# Altium schematic sheet powerpath_controller.SchDoc
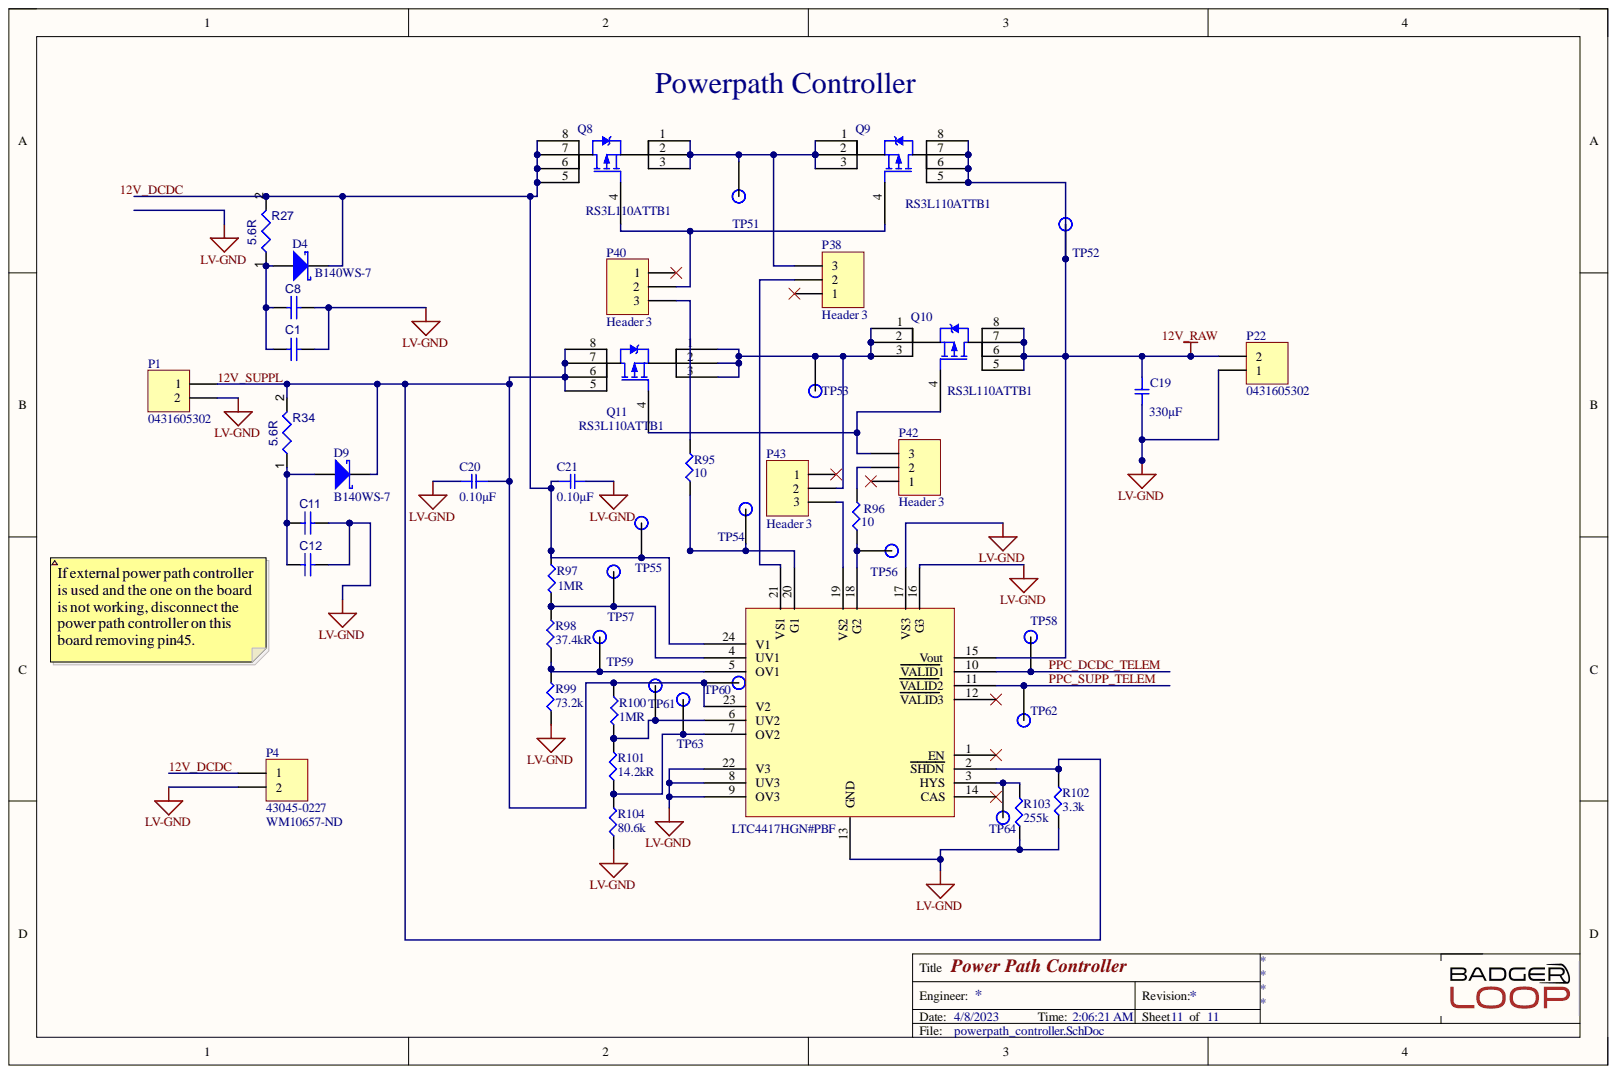
[diagram p0: powerpath_controller.SchDoc]

## Parts
C1  35YXJ2200M16X25  [35YXJ2200M16X25-Footprint-1]  (value not drawn)
C8  35YXJ2200M16X25  [35YXJ2200M16X25-Footprint-1]  (value not drawn)
C11  35YXJ2200M16X25  [35YXJ2200M16X25-Footprint-1]  (value not drawn)
C12  35YXJ2200M16X25  [35YXJ2200M16X25-Footprint-1]  (value not drawn)
C19  Capacitance: 330µF  [CAPAE110103X110N]  (hidden comment: GYB1E331MCW1GS)
C20  0.10µF  [SMD-0805C]
C21  0.10µF  [SMD-0805C]
D4  B140WS-7  [SODFL250X110-2N]
D9  B140WS-7  [SODFL250X110-2N]
P1  0431605302  [CONN HDR 2POS 7.50MM]
P4  43045-0227  Supplier Part Number 1: WM10657-ND  [43045-0277]
P22  0431605302  [CONN HDR 2POS 7.50MM]
P38  Header 3  [Pin Header 1x3 TH Pitch 2.54mm]
P40  Header 3  [Pin Header 1x3 TH Pitch 2.54mm]
P42  Header 3  [Pin Header 1x3 TH Pitch 2.54mm]
P43  Header 3  [Pin Header 1x3 TH Pitch 2.54mm]
Q8  RS3L110ATTB1  [RS3L110AT]
Q9  RS3L110ATTB1  [RS3L110AT]
Q10  RS3L110ATTB1  [RS3L110AT]
Q11  RS3L110ATTB1  [RS3L110AT]
R27  5.6R  [FP-RMCF2512-IPC_C]
R34  5.6R  [FP-RMCF2512-IPC_C]
R95  10  [SMD-0805-RES]
R96  10  [SMD-0805-RES]
R97  1MR  [SMD-0805-RES]
R98  37.4kR  [SMD-0805-RES]
R99  73.2k  [SMD-1206-RES]
R100  1MR  [SMD-0805-RES]
R101  14.2kR  [SMD-0805-RES]
R102  3.3k  [SMD-1206-RES]
R103  255k  [SMD-1206-RES]
R104  80.6k  [SMD-0805-RES]
TP51  TP  [Test Point]
TP52  TP  [Test Point]
TP53  TP  [Test Point]
TP54  TP  [Test Point]
TP55  TP  [Test Point]
TP56  TP  [Test Point]
TP57  TP  [Test Point]
TP58  TP  [Test Point]
TP59  TP  [Test Point]
TP60  TP  [Test Point]
TP61  TP  [Test Point]
TP62  TP  [Test Point]
TP63  TP  [Test Point]
TP64  TP  [Test Point]
U16  LTC4417HGN#PBF  [LT-GN-24_L]

## Nets
12V_RAW: C19.1 P22.2 Q9.5 Q9.6 Q9.7 Q9.8 Q10.5 Q10.6 Q10.7 Q10.8 TP52.1 U16.15(Vout)
LV-GND: C1.2 C8.2 C11.2 C12.2 C19.2 C20.2 C21.2 P1.2 P4.2 P22.1 R99.1 R102.1 R103.1 R104.1 U16.8(UV3) U16.9(OV3) U16.13(GND) U16.16(G3) U16.17(VS3) U16.22(V3)
12V_DCDC: P4.1
12V_SUPPL: C20.1 D9.2 P1.1 Q11.5 Q11.6 Q11.7 Q11.8 R34.2 R100.1 R102.2 TP60.1 U16.2(SHDN) U16.23(V2)
PPC_DCDC_TELEM: TP58.1 U16.10(VALID1)
PPC_SUPP_TELEM: TP62.1 U16.11(VALID2)
NetC1_1: C1.1 C8.1 D4.1 R27.1
NetC11_1: C11.1 C12.1 D9.1 R34.1
NetC21_1: C21.1 D4.2 Q8.5 Q8.6 Q8.7 Q8.8 R27.2 R97.1 TP55.1 U16.24(V1)
NetP38_2: P38.2 U16.21(VS1)
NetP38_3: P38.3 Q8.1 Q8.2 Q8.3 Q9.1 Q9.2 Q9.3 TP51.1
NetP40_2: P40.2 Q8.4 Q9.4
NetP40_3: P40.3 R95.2
NetP42_2: P42.2 R96.2
NetP42_3: P42.3 Q10.4 Q11.4
NetP43_2: P43.2 Q10.1 Q10.2 Q10.3 Q11.1 Q11.2 Q11.3 TP53.1
NetP43_3: P43.3 U16.19(VS2)
NetR95_1: R95.1 TP54.1 U16.20(G1)
NetR96_1: R96.1 TP56.1 U16.18(G2)
NetR97_2: R97.2 R98.2 TP57.1 U16.4(UV1)
NetR98_1: R98.1 R99.2 TP59.1 U16.5(OV1)
NetR100_2: R100.2 R101.2 TP61.1 U16.6(UV2)
NetR101_1: R101.1 R104.2 TP63.1 U16.7(OV2)
NetR103_2: R103.2 TP64.1 U16.3(HYS)

Not connected: P38 1; P40 1; P42 1; P43 1; U16 1(EN) 12(VALID3) 14(CAS)

## Library data of the parts
C1 C8 C11 C12 = CMP-001-00497-1: Aluminum Electrolytic Capacitor, Polarized, Aluminum (wet), 35V, 20% +Tol, 20% -Tol, 2200uF; Capacitor Type: Aluminium; Ripple Current: 2.93A; Component Kind: Standard; Library Name: Altium Concord Pro on A365; Max Operating Temperature: 105°C; Min Operating Temperature: -40°C; RoHS Compliant: Yes
C19 = GYB1E331MCW1GS: Aluminum Organic Polymer Capacitors 25V 330uf; Component Kind: Standard; ComponentLink1Description: Link; ComponentLink1URL: https://www.mouser.com/ProductDetail/Nichicon/GYB1E331MCW1GS?qs=sGAEpiMZZMvwFf0viD3Y3a3yb5D6sPUgteTcG6zlWVz7Qxll6G9Nxw%3D%3D; Library Name: capacitors.SchLib
C20 C21 = GCJ21BR71H104KA01L: CAP CER 0.1UF 50V X7R 0805; Manufacturer: Murata Electronics North America; @ qty: 0; Minimum Quantity: 1; Tolerance: ±10%; Unit Price (USD): 0.24; Applications: Automotive, Boardflex Sensitive; Component Kind: Standard; Datasheets: http://www.murata.com/~/media/webrenewal/support/library/catalog/products/capacitor/mlcc/c03e.ashx; Digi-Key Part Number: 490-5803-1-ND; Factory Stock: 0; Features: Soft Termination; Height - Seated (Max): -; Image: //media.digikey.com/Renders/Murata%20Renders/0805%20(2012%20Metric)%20.85mm.jpg; Lead Spacing: -; Lead Style: -; Library Name: capacitors.SchLib; Mounting Type: Surface Mount, MLCC; Operating Temperature: -55°C ~ 125°C; Package / Case: 0805 (2012 Metric); Packaging: Cut Tape (CT); Part Number: GCJ21BR71H104KA01L; Part Status: Active; Quantity Available: 700; Ratings: AEC-Q200; Series: GCJ; Size / Dimension: 0.079" L x 0.049" W (2.00mm x 1.25mm); Temperature Coefficient: X7R; Thickness (Max): 0.057" (1.45mm); Value: 0.10µF; Voltage: 50V
D4 D9 = CMP-004-00041-1: Diode Schottky 40V 1A 2-Pin SOD-323 T/R; Manufacturer: Diodes; Element Configuration: Single; Forward Voltage: 620mV; Max Forward Surge Current (Ifsm): 3A; Max Reverse Current: 50uA; Natural Thermal Resistance: 426°C/W; Average Rectified Current: 1A; Component Kind: Standard; ComponentLink1Description: http://www.mouser.com/ds/2/115/ds31543-56649.pdf?src-supplier=Mouser; ComponentLink1URL: http://www.mouser.com/ds/2/115/ds31543-56649.pdf?src-supplier=Mouser; ComponentLink2Description: http://www.diodes.com//datasheets/ds31543.pdf?src-supplier=Avnet+Europe; ComponentLink2URL: http://www.diodes.com//datasheets/ds31543.pdf?src-supplier=Avnet+Europe; ComponentLink3Description: http://www.diodes.com/datasheets/ds31543.pdf?src-supplier=Digi-Key; ComponentLink3URL: http://www.diodes.com/datasheets/ds31543.pdf?src-supplier=Digi-Key; Contact Plating: Tin,Matte; Design Item ID: B140WS-7; Forward Current: 1A; Height: 1.1mm; Length: 1.8mm; Library Name: Altium Concord Pro on A365; Max Operating Temperature: 125°C; Max Repetitive Reverse Voltage (Vrrm): 40V; Max Reverse Voltage (DC): 40V; Min Operating Temperature: -40°C; Mount: Surface Mount; Package Quantity: 3000; Packaging: Tape and Reel; Peak Non-Repetitive Surge Current: 3A; Pins: 2; Pricing 1: 1=0.41, 10=0.293, 100=0.1476, 500=0.13076, 1000=0.10176 (USD); RoHS Compliant: Yes; Series: -; Source: misc.SchLib; Stock 1: 38792; Weight: 0.000173oz; Width: 1.4mm
P1 P22 = 0431605302: Conn Power HDR 2 POS 7.49mm Solder RA Thru-Hole 4 Terminal 1 Port Sabre™ Tray; Manufacturer: Molex; Contact Resistance: 30mR; Current Rating: 18A; Number of Contacts: 2; Number of Ports: 1; Voltage Rating: 600V; Color: Black; Component Kind: Standard; ComponentLink1Description: https://www.verical.com/server-webapp/html/getDatasheet?partID=198412&src-supplier=Verical; ComponentLink1URL: https://www.verical.com/server-webapp/html/getDatasheet?partID=198412&src-supplier=Verical; ComponentLink2Description: http://components.arrow.com/part/dataview/2942820S6220968N3303?src-supplier=Arrow; ComponentLink2URL: http://components.arrow.com/part/dataview/2942820S6220968N3303?src-supplier=Arrow; ComponentLink3Description: http://www.molex.com/pdm_docs/ps/PSX-43335-9999.pdf?src-supplier=Digi-Key; ComponentLink3URL: http://www.molex.com/pdm_docs/ps/PSX-43335-9999.pdf?src-supplier=Digi-Key; Contact Material: Brass; Contact Plating: Tin,Copper; Depth: 19.79mm; Fastening Type: Ramp; Housing Color: Black; Housing Material: Nylon; Insulation Material: Nylon; Length: 21.08mm; Library Name: connector.SchLib; Manufacturer Part Number: 0431605302; Max Operating Temperature: 75°C; Min Operating Temperature: -40°C; Mount: Through Hole; Number of Positions: 2; Number of Rows: 1; Number of Terminals: 4; Orientation: Right Angle; Package Quantity: 525; Packaging: Tray; Pitch: 7.49mm; Stock 1: 0; Supplier 1: Digi-Key; Supplier Part Number 1: WM13983-ND; Termination: Solder
P4 = 43045-0227: Board Connector, 2 Contact(s), 2 Row(s), Male, Straight, Solder Terminal, Locking, Black Insulator, Receptacle; Manufacturer: Molex; Board Mounting Option: PEG; Body Breadth: 0.29inch; Body Depth: 0.39inch; Body Length: 0.262inch; Contact Resistance: 10mR; Contact Style: SQ PIN-SKT; DIN Conformance: No; Insertion Force-Max: 8.0064N; Insulation Resistance: 1GR; Mating Information: MULTIPLE MATING PARTS AVAILABLE; Number Of Connectors: 1; Number of Contacts: 2; Option: General Purpose; Plating Thickness: 6uin; Rated Current (Signal): 2.5A; Reference Standard: UL; Reliability: COMMERCIAL; Throw Configuration: S0P2; Withdrawl Force-Min: 3.5584N; Body/Shell Style: RECEPTACLE; Category: Headers and Wire Housings; Component Kind: Standard; ComponentLink1Description: https://datasheet.ciiva.com:443/pdfs/VipMasterIC/IC/MOLE/MOLE-S-A0001360181/MOLE-S-A0001399497-1.pdf?src-supplier=IHS+Markit; ComponentLink1URL: https://datasheet.ciiva.com:443/pdfs/VipMasterIC/IC/MOLE/MOLE-S-A0001360181/MOLE-S-A0001399497-1.pdf?src-supplier=IHS+Markit; ComponentLink2Description: https://datasheet.ciiva.com/5148/0430450227-pcb-headers-142611-5148694.pdf?src-supplier=Mouser; ComponentLink2URL: https://datasheet.ciiva.com/5148/0430450227-pcb-headers-142611-5148694.pdf?src-supplier=Mouser; ComponentLink3Description: https://datasheet.ciiva.com/16103/307170430450227-pcb-headers-16103122.pdf?src-supplier=Onlinecomponents.com; ComponentLink3URL: https://datasheet.ciiva.com/16103/307170430450227-pcb-headers-16103122.pdf?src-supplier=Onlinecomponents.com; ComponentLink4Description: https://datasheet.ciiva.com/11752/0900766b80d4a80b-11752890.pdf?src-supplier=RSComponents; ComponentLink4URL: https://datasheet.ciiva.com/11752/0900766b80d4a80b-11752890.pdf?src-supplier=RSComponents; ComponentLink5Description: https://datasheet.ciiva.com/30256/212010430450227-pcb-headers-30256881.pdf?src-supplier=Onlinecomponents.com; ComponentLink5URL: https://datasheet.ciiva.com/30256/212010430450227-pcb-headers-30256881.pdf?src-supplier=Onlinecomponents.com; ComponentLink6Description: https://datasheet.ciiva.com/16725/0430450227-pcb-headers-16725483.pdf?src-supplier=Avnet+Europe; ComponentLink6URL: https://datasheet.ciiva.com/16725/0430450227-pcb-headers-16725483.pdf?src-supplier=Avnet+Europe; ComponentLink7Description: https://datasheet.ciiva.com/10089/0430450227-pcb-headers-10089453.pdf?src-supplier=Avnet; ComponentLink7URL: https://datasheet.ciiva.com/10089/0430450227-pcb-headers-10089453.pdf?src-supplier=Avnet; Connector Type: BOARD CONNECTOR; Contact Finish - Mating: TIN (100) OVER NICKEL; Contact Finish - Termination: TIN (100) OVER NICKEL; Contact Gender: Male; Contact Material: Brass; Filter Feature: No; Flammability Rating: UL94 V-0; IEC Conformance: No; Insulation Color: Black; Insulation Material: Polymer; JESD-609 Code: e3; Library Name: connector.SchLib; Manufacturer Part Number: 43045-0227; Max Operating Temperature: 105°C; MIL Conformance: No; Min Operating Temperature: -40°C; Mixed Contacts: No; Mount: Board; Mounting Option 1: LOCKING; Mounting Style: STRAIGHT; Number of Rows Loaded: 2; Pricing 1: 1=0.97000, 10=0.80600, 80=0.67200, 945=0.53760, 2400=0.48720 (USD); REACH SVHC: Yes; RoHS: Yes; RoHS Compliant: Yes; Stock 1: 12970; Supplier 1: Digi-Key; Terminal Length: 0.125inch; Terminal Pitch: 3mm; Termination: Solder
P38 P40 P42 P43 = CMP-002-00073-1: Header, 3-Pin; Component Kind: Standard; Design Item ID: Header 3; LatestRevisionDate: 17-Jul-2002; LatestRevisionNote: Re-released for DXP Platform.; Library Name: Altium Concord Pro on A365; Source: connector.SchLib; Type: Connector; Publisher: Altium Limited
Q8 Q11 = CMP-008-000012-4: Transistor MOSFET P-Channel -60V ±11A 8-Pin SOP T/R; Transistor Type: P-channel MOSFET; Component Kind: Standard; Library Name: Altium Concord Pro on A365
Q9 Q10 = CMP-008-000012-4: Transistor MOSFET P-Channel -60V ±11A 8-Pin SOP T/R; __ORIG_LIFECYCLESTATEGUID__: 706004B5-C76E-482E-903B-BA0E4FAE086A; Transistor Type: P-channel MOSFET; Component Kind: Standard; Library Name: Altium Concord Pro on A365
R27 R34 = CMP-009-02795-1: Fixed Resistor, Metal Glaze/thick Film, 1W, 5.6ohm, 200V, 5% +/-Tol, 400ppm/Cel, Surface Mount, 2512; Component Kind: Standard; Library Name: Altium Concord Pro on A365; Max Operating Temperature: 155°C; Min Operating Temperature: -55°C; RoHS Compliant: Yes; Tolerance: 5%
R95 R96 = ERJ-6GEYJ100V: RES SMD 10 OHM 5% 1/8W 0805; Manufacturer: Panasonic Electronic Components; @ qty: 1500; Factory Stock: 0; Minimum Quantity: 1; Power (Watts): 0.125W, 1/8W; Temperature Coefficient: ±200ppm/°C; Unit Price (USD): 0.00496; Component Kind: Standard; Composition: Thick Film; Datasheets: http://industrial.panasonic.com/www-cgi/jvcr13pz.cgi?E+PZ+3+AOA0001+ERJ6GEYJ100V+7+WW; Digi-Key Part Number: P10ACT-ND; Failure Rate: -; Features: Automotive AEC-Q200; Height - Seated (Max): 0.028" (0.70mm); Image: //media.digikey.com/Renders/Panasonic%20Renders/ERJ-0805%20Pkg.jpg; Library Name: resistors.SchLib; Number of Terminations: 2; Operating Temperature: -55°C ~ 155°C; Package / Case: 0805 (2012 Metric); Packaging: Cut Tape (CT); Part Number: ERJ-6GEYJ100V; Part Status: Active; Quantity Available: 282033; Series: ERJ; Size / Dimension: 0.079" L x 0.049" W (2.00mm x 1.25mm); Supplier Device Package: 0805; Tolerance: ±5%; Value: 10
R97 R100 = CRCW08051M00FKEAC: RES 1M OHM 1% 1/8W 0805; Manufacturer: Vishay; Package Height: 0.5mm; Package Length: 2mm; Package Width: 1.25mm; Rated Power Dissipation (P): 125mW; Temperature Coefficient: -100100ppm/°C; Working Voltage: 150V; Category: Chip SMD Resistors; Component Kind: Standard; ComponentLink1Description: https://datasheet.ciiva.com:443/pdfs/VipMasterIC/IC/VISH/VISH-S-A0003599164/VISH-S-A0003599164-1.pdf?src-supplier=IHS+Markit; ComponentLink1URL: https://datasheet.ciiva.com:443/pdfs/VipMasterIC/IC/VISH/VISH-S-A0003599164/VISH-S-A0003599164-1.pdf?src-supplier=IHS+Markit; ComponentLink2Description: http://www.vishay.com/docs/28773/crcwce3.pdf?src-supplier=Digi-Key; ComponentLink2URL: http://www.vishay.com/docs/28773/crcwce3.pdf?src-supplier=Digi-Key; ComponentLink3Description: http://www.vishay.com/docs/20035/dcrcwe3.pdf?src-supplier=Avnet; ComponentLink3URL: http://www.vishay.com/docs/20035/dcrcwe3.pdf?src-supplier=Avnet; Construction: Chip; JESD-609 Code: e3; Library Name: resistors.SchLib; Manufacturer Part Number: CRCW08051M00FKEAC; Max Operating Temperature: 155°C; Min Operating Temperature: -55°C; Number of Pins: 2; Package Style: SMTMeter; Packaging: Tape and Reel; Pricing 1: 1=0.10000, 10=0.06300, 100=0.02540, 1000=0.01143, 2500=0.00992 (USD); REACH SVHC: Yes; Resistance: 1MR; Resistor Type: FIXED RESISTOR; RoHS: Yes; RoHS Compliant: Yes; Size Code: 0805; Stock 1: 15539; Supplier 1: Digi-Key; Supplier Part Number 1: 541-4135-1-ND; Technology: METAL GLAZE/THICK FILM; Terminal Finish: Nickel; Tolerance: 1%
R98 = CRCW080537K4FKEA: RES SMD 37.4K OHM 1% 1/8W 0805; Manufacturer: Vishay; Package Height: 0.45mm; Package Length: 2mm; Package Width: 1.25mm; Rated Power Dissipation (P): 125mW; Rated Temperature: 70°C; Reference Standard: AEC-Q200; Temperature Coefficient: 100ppm/°C; Working Voltage: 150V; Category: Chip SMD Resistors; Component Kind: Standard; ComponentLink1Description: https://datasheet.ciiva.com:443/pdfs/VipMasterIC/IC/VISH/VISH-S-A0007266705/VISH-S-A0007266705-1.pdf?src-supplier=IHS+Markit; ComponentLink1URL: https://datasheet.ciiva.com:443/pdfs/VipMasterIC/IC/VISH/VISH-S-A0007266705/VISH-S-A0007266705-1.pdf?src-supplier=IHS+Markit; ComponentLink2Description: https://datasheet.ciiva.com/9012/dcrcwe3-9012831.pdf?src-supplier=Avnet; ComponentLink2URL: https://datasheet.ciiva.com/9012/dcrcwe3-9012831.pdf?src-supplier=Avnet; ComponentLink3Description: https://datasheet.ciiva.com/7725/66915-7725943.pdf?src-supplier=Element14; ComponentLink3URL: https://datasheet.ciiva.com/7725/66915-7725943.pdf?src-supplier=Element14; ComponentLink4Description: https://datasheet.ciiva.com/22705/1697337-22705877.pdf?src-supplier=Element14; ComponentLink4URL: https://datasheet.ciiva.com/22705/1697337-22705877.pdf?src-supplier=Element14; ComponentLink5Description: https://datasheet.ciiva.com/22674/1912744-22674621.pdf?src-supplier=Element14; ComponentLink5URL: https://datasheet.ciiva.com/22674/1912744-22674621.pdf?src-supplier=Element14; ComponentLink6Description: https://datasheet.ciiva.com/7671/1697337-7671694.pdf?src-supplier=Element14; ComponentLink6URL: https://datasheet.ciiva.com/7671/1697337-7671694.pdf?src-supplier=Element14; ComponentLink7Description: https://datasheet.ciiva.com/7671/1697337-7671695.pdf?src-supplier=Element14; ComponentLink7URL: https://datasheet.ciiva.com/7671/1697337-7671695.pdf?src-supplier=Element14; ComponentLink8Description: https://datasheet.ciiva.com/16395/dcrcwe3-16395353.pdf?src-supplier=Future+Electronics; ComponentLink8URL: https://datasheet.ciiva.com/16395/dcrcwe3-16395353.pdf?src-supplier=Future+Electronics; ComponentLink9Description: https://datasheet.ciiva.com/2525/dcrcwe3-2525821.pdf?src-supplier=Digi-Key; ComponentLink9URL: https://datasheet.ciiva.com/2525/dcrcwe3-2525821.pdf?src-supplier=Digi-Key; ComponentLink10Description: https://datasheet.ciiva.com/2525/dcrcwe3-2525822.pdf?src-supplier=Digi-Key; ComponentLink10URL: https://datasheet.ciiva.com/2525/dcrcwe3-2525822.pdf?src-supplier=Digi-Key; ComponentLink11Description: https://datasheet.ciiva.com/2525/dcrcwe3-2525823.pdf?src-supplier=Digi-Key; ComponentLink11URL: https://datasheet.ciiva.com/2525/dcrcwe3-2525823.pdf?src-supplier=Digi-Key; Construction: Chip; JESD-609 Code: e3; Library Name: resistors.SchLib; Manufacturer Part Number: CRCW080537K4FKEA; Max Operating Temperature: 155°C; Min Operating Temperature: -55°C; Mount: Surface Mount; Number of Pins: 2; Package Shape: Rectangular; Package Style: SMTMeter; Packaging: Tape and Reel; Pricing 1: 1=0.10000, 10=0.06700, 100=0.02710, 1000=0.01219, 2500=0.01058 (USD); REACH SVHC: Yes; Resistance: 37.4kR; Resistor Type: FIXED RESISTOR; RoHS: Yes; RoHS Compliant: Yes; Size Code: 0805; Stock 1: 12211; Supplier 1: Digi-Key; Supplier Part Number 1: 541-37.4KCCT-ND; Technology: METAL GLAZE/THICK FILM; Terminal Finish: Nickel; Termination: Wraparound; Tolerance: 1%
R99 = ERJ-8ENF7322V: RES SMD 73.2K OHM 1% 1/4W 1206; Manufacturer: Panasonic Electronic Components; @ qty: 1500; Factory Stock: 0; Minimum Quantity: 1; Power (Watts): 0.25W, 1/4W; Temperature Coefficient: ±100ppm/°C; Unit Price (USD): 0.00933; Component Kind: Standard; Composition: Thick Film; Datasheets: http://industrial.panasonic.com/www-cgi/jvcr13pz.cgi?E+PZ+3+AOA0002+ERJ8ENF7322V+7+WW; Digi-Key Part Number: P73.2KFCT-ND; Failure Rate: -; Features: Automotive AEC-Q200; Height - Seated (Max): 0.028" (0.70mm); Image: //media.digikey.com/Renders/Panasonic%20Renders/ERJ-1206%20Pkg.jpg; Library Name: resistors.SchLib; Number of Terminations: 2; Operating Temperature: -55°C ~ 155°C; Package / Case: 1206 (3216 Metric); Packaging: Cut Tape (CT); Part Number: ERJ-8ENF7322V; Part Status: Active; Quantity Available: 19226; Series: ERJ; Size / Dimension: 0.126" L x 0.063" W (3.20mm x 1.60mm); Supplier Device Package: 1206; Tolerance: ±1%; Value: 73.2k
R101 = TNPW080514K2BEEA: RES 14.2K OHM 0.1% 1/5W 0805; Manufacturer: Vishay; Package Height: 0.45mm; Package Length: 2mm; Package Width: 1.25mm; Rated Power Dissipation (P): 125mW; Rated Temperature: 70°C; Reference Standard: AEC-Q200; Temperature Coefficient: 25ppm/°C; Working Voltage: 150V; Category: Chip SMD Resistors; Component Kind: Standard; ComponentLink1Description: https://datasheet.ciiva.com:443/pdfs/VipMasterIC/IC/VISH/VISH-S-A0002290253/VISH-S-A0002290253-1.pdf?src-supplier=IHS+Markit; ComponentLink1URL: https://datasheet.ciiva.com:443/pdfs/VipMasterIC/IC/VISH/VISH-S-A0002290253/VISH-S-A0002290253-1.pdf?src-supplier=IHS+Markit; ComponentLink2Description: http://www.mouser.com/ds/2/427/tnpw_e3-64594.pdf?src-supplier=Mouser; ComponentLink2URL: http://www.mouser.com/ds/2/427/tnpw_e3-64594.pdf?src-supplier=Mouser; ComponentLink3Description: http://www.vishay.com/docs/28758/tnpw_e3.pdf?src-supplier=Avnet; ComponentLink3URL: http://www.vishay.com/docs/28758/tnpw_e3.pdf?src-supplier=Avnet; ComponentLink4Description: http://www.vishay.com/docs/28758/tnpw_e3.pdf?src-supplier=Digi-Key; ComponentLink4URL: http://www.vishay.com/docs/28758/tnpw_e3.pdf?src-supplier=Digi-Key; ComponentLink5Description: http://www.vishay.com/docs/28758/tnpw_e3.pdf?src-supplier=Future+Electronics; ComponentLink5URL: http://www.vishay.com/docs/28758/tnpw_e3.pdf?src-supplier=Future+Electronics; Construction: Chip; Library Name: resistors.SchLib; Manufacturer Part Number: TNPW080514K2BEEA; Max Operating Temperature: 125°C; Min Operating Temperature: -55°C; Mount: Surface Mount; Number of Pins: 2; Package Style: SMTMeter; Packaging: Tape and Reel; Pricing 1: 1=0.75000, 10=0.54900, 50=0.54900, 100=0.35000, 1000=0.22100, 10000=0.21500 (USD); REACH SVHC: Yes; Resistance: 14.2kR; Resistor Type: FIXED RESISTOR; RoHS: Yes; RoHS Compliant: Yes; Size Code: 0805; Stock 1: 1469; Supplier 1: Mouser; Supplier Part Number 1: 71-TNPW080514K2BEEA; Technology: THIN FILM; Terminal Finish: Nickel; Termination: Wraparound; Tolerance: 0.1%
R102 = ERJ-8ENF3301V: RES SMD 3.3K OHM 1% 1/4W 1206; Manufacturer: Panasonic Electronic Components; @ qty: 1500; Factory Stock: 0; Minimum Quantity: 1; Power (Watts): 0.25W, 1/4W; Temperature Coefficient: ±100ppm/°C; Unit Price (USD): 0.00933; Component Kind: Standard; Composition: Thick Film; Datasheets: http://industrial.panasonic.com/www-cgi/jvcr13pz.cgi?E+PZ+3+AOA0002+ERJ8ENF3301V+7+WW; Digi-Key Part Number: P3.30KFCT-ND; Failure Rate: -; Features: Automotive AEC-Q200; Height - Seated (Max): 0.028" (0.70mm); Image: //media.digikey.com/Renders/Panasonic%20Renders/ERJ-1206%20Pkg.jpg; Library Name: resistors.SchLib; Number of Terminations: 2; Operating Temperature: -55°C ~ 155°C; Package / Case: 1206 (3216 Metric); Packaging: Cut Tape (CT); Part Number: ERJ-8ENF3301V; Part Status: Active; Quantity Available: 48879; Series: ERJ; Size / Dimension: 0.126" L x 0.063" W (3.20mm x 1.60mm); Supplier Device Package: 1206; Tolerance: ±1%; Value: 3.3k
R103 = ERJ-8ENF2553V: RES SMD 255K OHM 1% 1/4W 1206; Manufacturer: Panasonic Electronic Components; @ qty: 1500; Factory Stock: 0; Minimum Quantity: 1; Power (Watts): 0.25W, 1/4W; Temperature Coefficient: ±100ppm/°C; Unit Price (USD): 0.00933; Component Kind: Standard; Composition: Thick Film; Datasheets: http://industrial.panasonic.com/www-cgi/jvcr13pz.cgi?E+PZ+3+AOA0002+ERJ8ENF2553V+7+WW; Digi-Key Part Number: P255KFCT-ND; Failure Rate: -; Features: Automotive AEC-Q200; Height - Seated (Max): 0.028" (0.70mm); Image: //media.digikey.com/Renders/Panasonic%20Renders/ERJ-1206%20Pkg.jpg; Library Name: resistors.SchLib; Number of Terminations: 2; Operating Temperature: -55°C ~ 155°C; Package / Case: 1206 (3216 Metric); Packaging: Cut Tape (CT); Part Number: ERJ-8ENF2553V; Part Status: Active; Quantity Available: 49543; Series: ERJ; Size / Dimension: 0.126" L x 0.063" W (3.20mm x 1.60mm); Supplier Device Package: 1206; Tolerance: ±1%; Value: 255k
R104 = ERJ-6ENF8062V: RES SMD 80.6K OHM 1% 1/8W 0805; Manufacturer: Panasonic Electronic Components; @ qty: 1500; Factory Stock: 0; Minimum Quantity: 1; Power (Watts): 0.125W, 1/8W; Temperature Coefficient: ±100ppm/°C; Unit Price (USD): 0.00729; Component Kind: Standard; Composition: Thick Film; Datasheets: http://industrial.panasonic.com/www-cgi/jvcr13pz.cgi?E+PZ+3+AOA0002+ERJ6ENF8062V+7+WW; Digi-Key Part Number: P80.6KCCT-ND; Failure Rate: -; Features: Automotive AEC-Q200; Height - Seated (Max): 0.028" (0.70mm); Image: //media.digikey.com/Renders/Panasonic%20Renders/ERJ-0805%20Pkg.jpg; Library Name: resistors.SchLib; Number of Terminations: 2; Operating Temperature: -55°C ~ 155°C; Package / Case: 0805 (2012 Metric); Packaging: Cut Tape (CT); Part Number: ERJ-6ENF8062V; Part Status: Active; Quantity Available: 48051; Series: ERJ; Size / Dimension: 0.079" L x 0.049" W (2.00mm x 1.25mm); Supplier Device Package: 0805; Tolerance: ±1%; Value: 80.6k
TP51-TP64 = TP: SMD TEST POINT; Component Kind: Standard; Library Name: misc.SchLib
U16 = CMP-008-000010-2: Power Supply Support Circuit, Adjustable, 3 Channel, CMOS, PDSO24; Component Kind: Standard; Library Name: Altium Concord Pro on A365
